# Altium schematic sheet Do.SchDoc
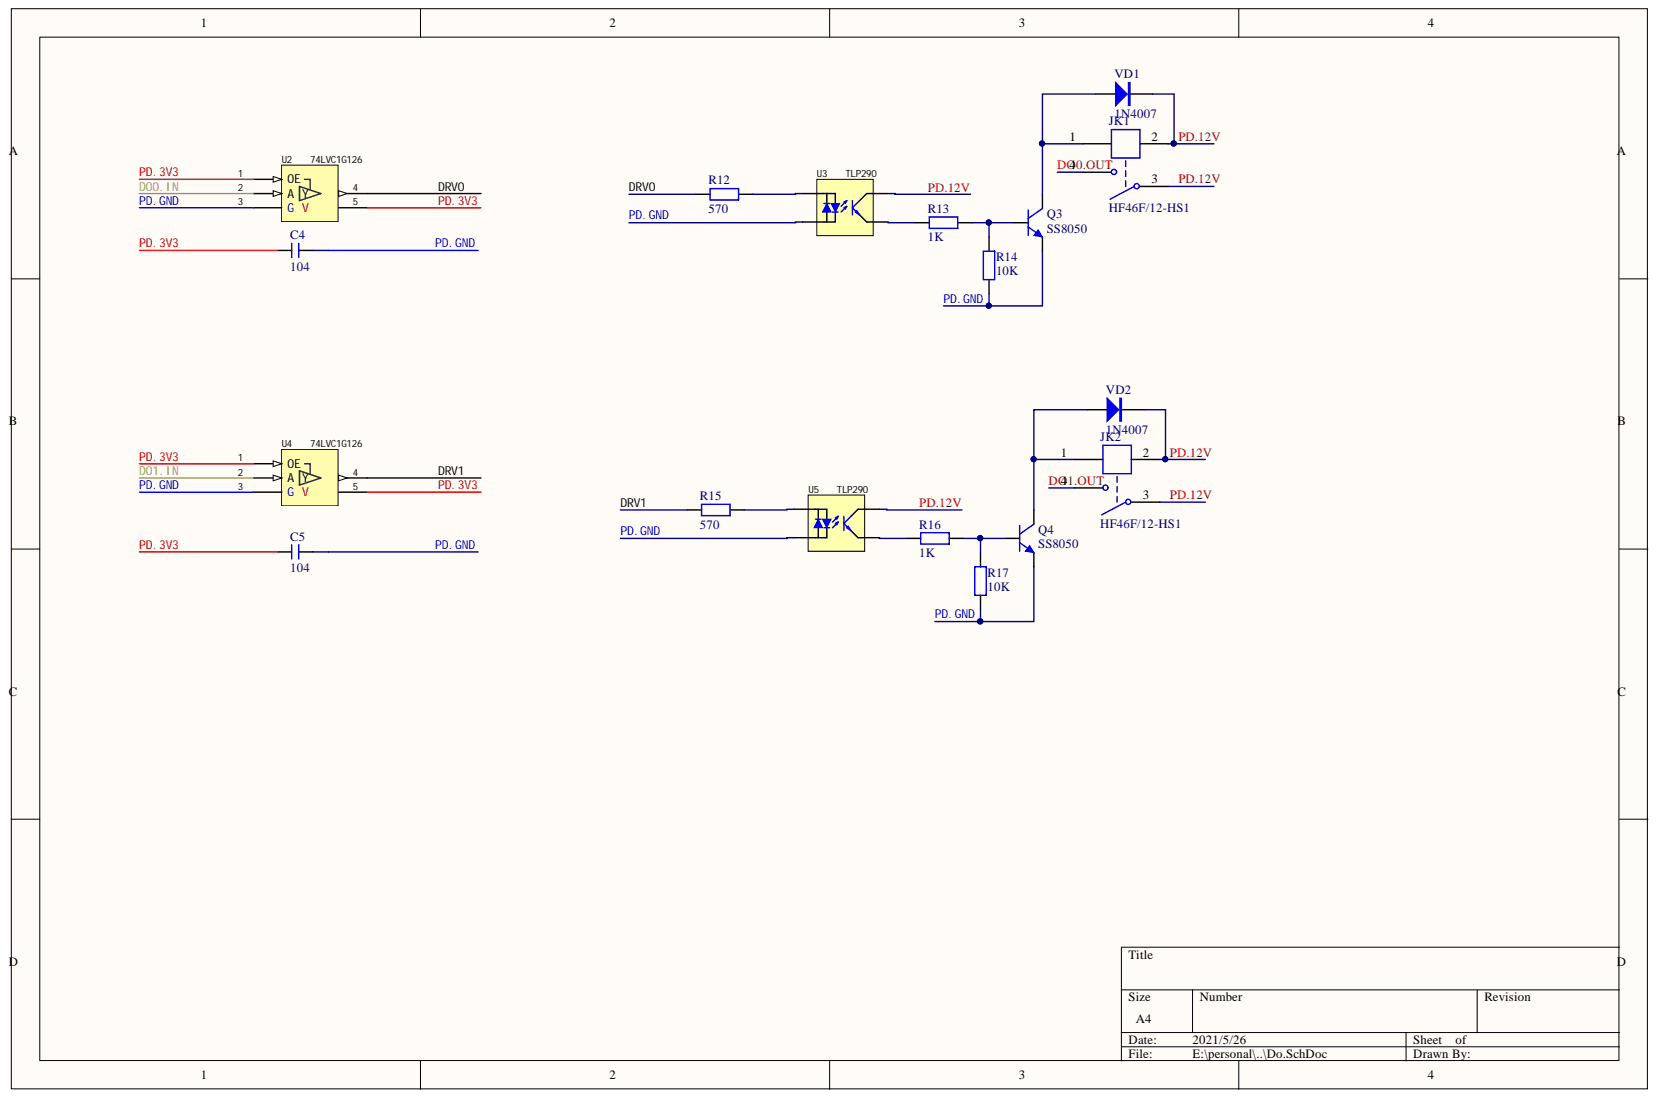
[diagram p0: Do.SchDoc]

## Parts
C4  104  [C 0603]
C5  104  [C 0603]
JK1  HF46F/12-HS1  [HF46F/12-HS1]
JK2  HF46F/12-HS1  [HF46F/12-HS1]
Q3  SS8050  [SOT23-3N]
Q4  SS8050  [SOT23-3N]
R12  570  [R 0603]
R13  1K  [R 0603]
R14  10K  [R 0603]
R15  570  [R 0603]
R16  1K  [R 0603]
R17  10K  [R 0603]
U2  74LVC1G126  [TSSOP-5P-0.65BSC-2.15x1.40Bmax-1.10Hmax]
U3  TLP290  [SO-4-1.27BSC-4.6W-TLP]
U4  74LVC1G126  [TSSOP-5P-0.65BSC-2.15x1.40Bmax-1.10Hmax]
U5  TLP290  [SO-4-1.27BSC-4.6W-TLP]
VD1  1N4007  [SMA]
VD2  1N4007  [SMA]

## Nets
DO0.IN: U2.2(A)
DO0.OUT: JK1.4
DO1.IN: U4.2(A)
DO1.OUT: JK2.4
DRV0: R12.1 U2.4
DRV1: R15.1 U4.4
PD.3V3: C4.2 C5.2 U2.1(OE) U2.5 U4.1(OE) U4.5
PD.12V: JK1.2 JK1.3 JK2.2 JK2.3 U3.4 U5.4 VD1.2 VD2.2
PD.GND: C4.1 C5.1 Q3.2 Q4.2 R14.1 R17.1 U2.3(G) U3.2 U4.3(G) U5.2
NetJK1_1: JK1.1 Q3.3 VD1.1
NetJK2_1: JK2.1 Q4.3 VD2.1
NetQ3_1: Q3.1 R13.2 R14.2
NetQ4_1: Q4.1 R16.2 R17.2
NetR12_2: R12.2 U3.1
NetR13_1: R13.1 U3.3
NetR15_2: R15.2 U5.1
NetR16_1: R16.1 U5.3

## Library data of the parts
C4 C5 = C: 无极性贴片电容; 版权归属: 源创客; BOM名称: 无极性贴片电容; Component Kind: Standard; Library Name: 电阻-电容-电感.SchLib; 学习资源: www.ooocke.com
JK1 JK2 = HF46F/12-HS1: 12V一组常开; BOM名称: 12V继电器; 版权归属: 源创客; Component Kind: Standard; Library Name: 7.1   - 继电器.SchLib; 学习资源: www.ooocke.com
Q3 Q4 = 8050-SMD: 高频放大-NPN型; 属性: 40V 1.5A 1W 100MHZ; 版权归属: 源创客; BOM名称: 高频放大-NPN型; Component Kind: Standard; Library Name: 三极管.SchLib; 学习资源: www.ooocke.com
R12-R17 = R: 贴片电阻; 版权归属: 源创客; BOM名称: 贴片电阻; Component Kind: Standard; Library Name: 电阻-电容-电感.SchLib; 学习资源: www.ooocke.com
U2 U4 = 74LVC1G126: U-LVC1G126; 02_CMT: NXP GW, TM: VN; TI DCK, TM: CN; 10_Code: C55052; 11_Name: 贴装芯片_Nexperia_74LVC1G126GW; Component Kind: Standard; Library Name: TKAI0163WP01-01.IntLib
U3 U5 = TLP290: U-TLP290; 10_Code: C424197; 11_Name: 贴装芯片_TLP290; Component Kind: Standard; Library Name: TKAI0163WP01-01.IntLib
VD1 VD2 = 1N4007: 整流二极管; BOM名称: 1N4148WS; 版权归属: 源创客; Component Kind: Standard; Library Name: 二极管-整流桥.SchLib; 学习资源: www.ooocke.com
